# Altium schematic sheet Engine Bay and Rear Tube.SchDoc
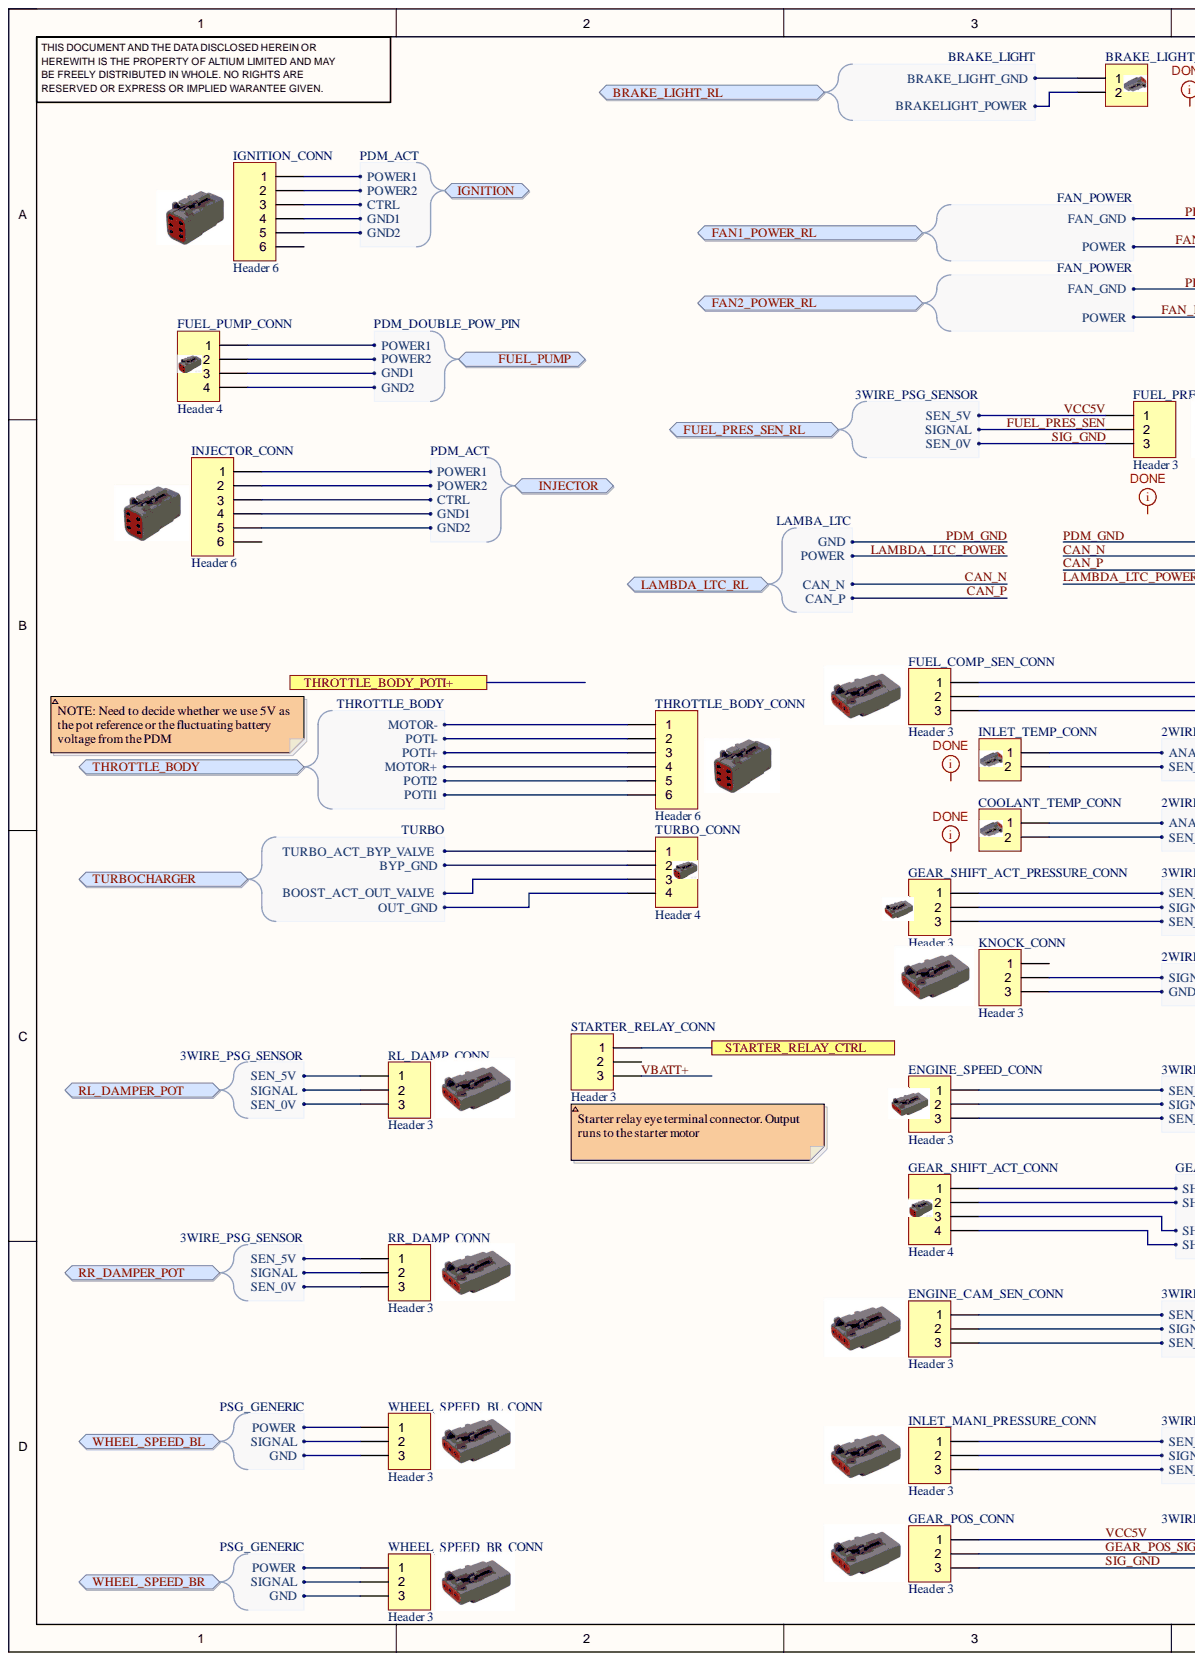
[diagram p0: Engine Bay and Rear Tube.SchDoc, left]
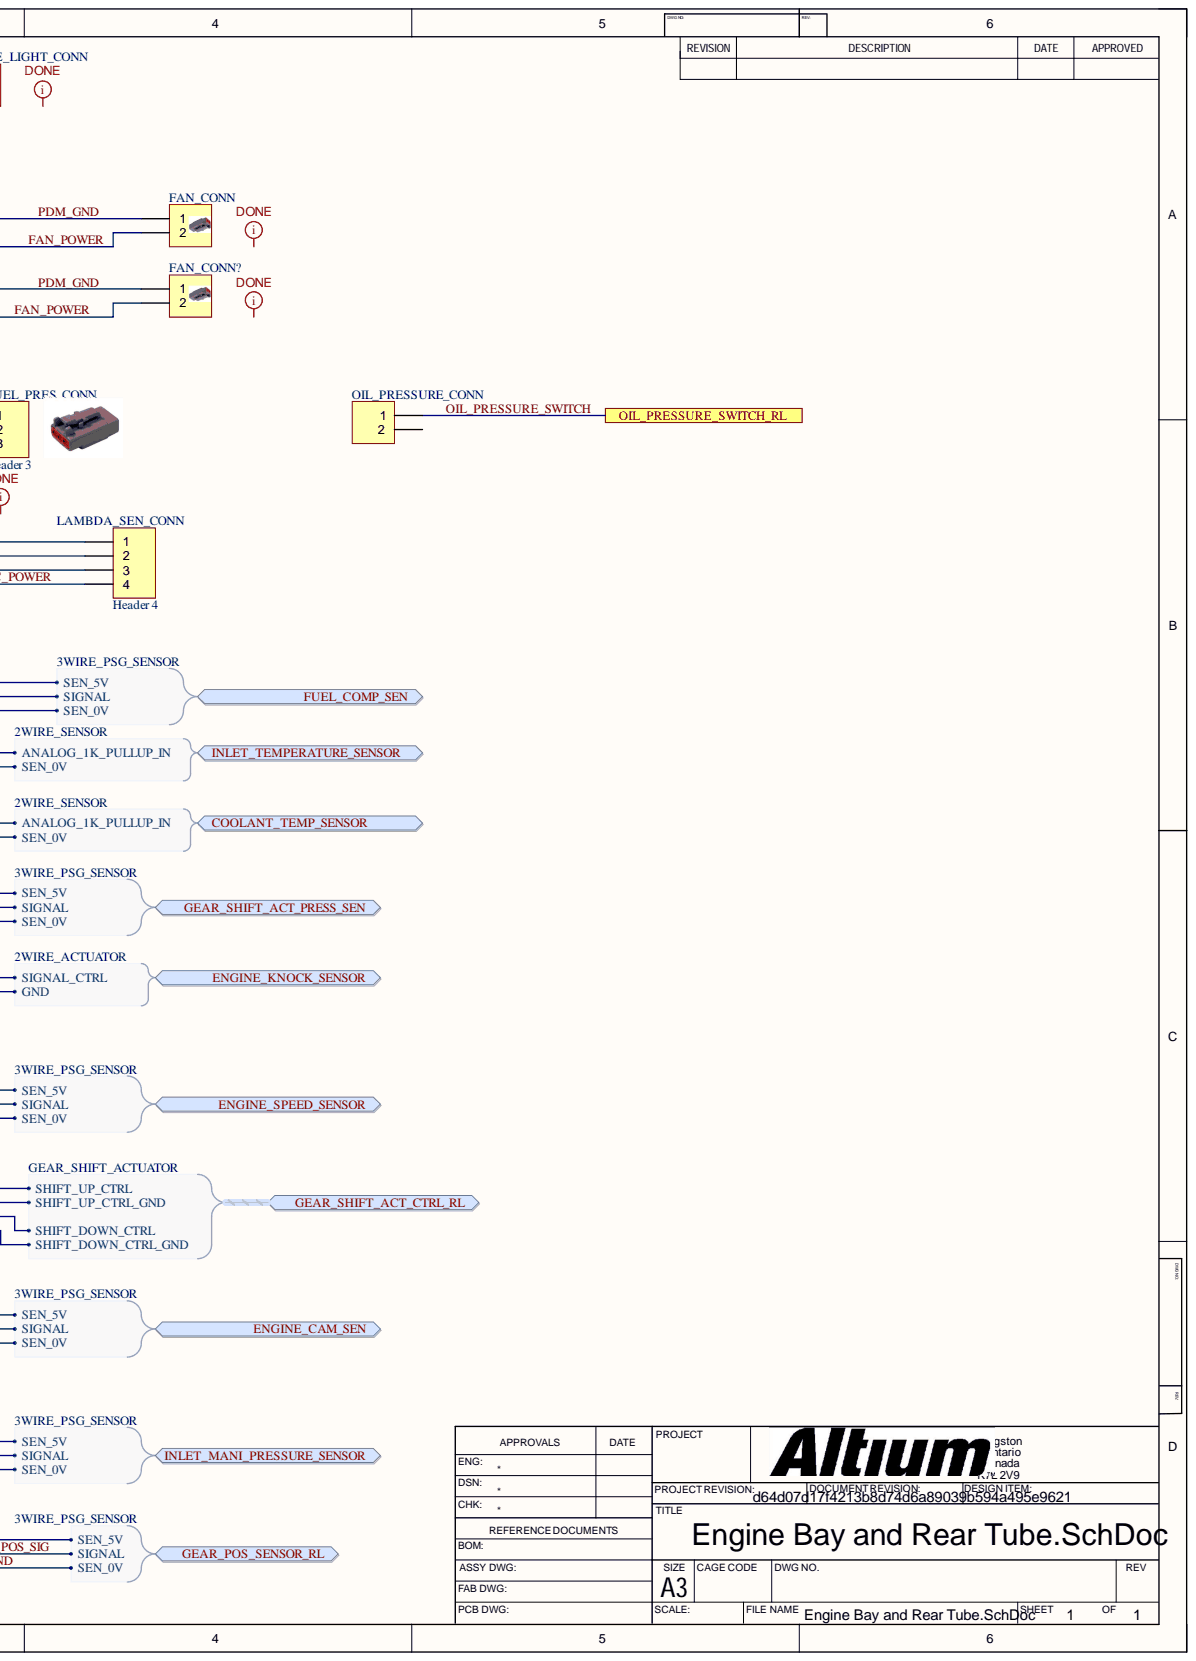
[diagram p1: Engine Bay and Rear Tube.SchDoc, right]

## Parts
BRAKE_LIGHT_CONN  Header 2  [HDR1X2]  (value not drawn)
COOLANT_TEMP_CONN  Header 2  [HDR1X2]  (value not drawn)
ENGINE_CAM_SEN_CONN  Header 3  [HDR1X3]
ENGINE_SPEED_CONN  Header 3  [HDR1X3]
FAN_CONN  Header 2  [HDR1X2]  (value not drawn)
FAN_CONN?  Header 2  [HDR1X2]  (value not drawn)
FUEL_COMP_SEN_CONN  Header 3  [HDR1X3]
FUEL_PRES_CONN  Header 3  [HDR1X3]
FUEL_PUMP_CONN  Header 4  [HDR1X4]
GEAR_POS_CONN  Header 3  [HDR1X3]
GEAR_SHIFT_ACT_CONN  Header 4  [HDR1X4]
GEAR_SHIFT_ACT_PRESSURE_CONN  Header 3  [HDR1X3]
IGNITION_CONN  Header 6  [HDR1X6]
INJECTOR_CONN  Header 6  [HDR1X6]
INLET_MANI_PRESSURE_CONN  Header 3  [HDR1X3]
INLET_TEMP_CONN  Header 2  [HDR1X2]
KNOCK_CONN  Header 3  [HDR1X3]
LAMBDA_SEN_CONN  Header 4  [HDR1X4]
OIL_PRESSURE_CONN  Header 2  [HDR1X2]  (value not drawn)
RL_DAMP_CONN  Header 3  [HDR1X3]
RR_DAMP_CONN  Header 3  [HDR1X3]
STARTER_RELAY_CONN  Header 3  [HDR1X3]
THROTTLE_BODY_CONN  Header 6  [HDR1X6]
TURBO_CONN  Header 4  [HDR1X4]
WHEEL_SPEED_BL_CONN  Header 3  [HDR1X3]
WHEEL_SPEED_BR_CONN  Header 3  [HDR1X3]

## Ports
BRAKE_LIGHT_RL, BRAKE_LIGHT_RL.BRAKE_LIGHT_GND, BRAKE_LIGHT_RL.BRAKELIGHT_POWER, COOLANT_TEMP_SENSOR, COOLANT_TEMP_SENSOR.ANALOG_1K_PULLUP_IN, COOLANT_TEMP_SENSOR.SEN_0V, ENGINE_CAM_SEN, ENGINE_CAM_SEN.SEN_0V, ENGINE_CAM_SEN.SEN_5V, ENGINE_CAM_SEN.SIGNAL, ENGINE_KNOCK_SENSOR, ENGINE_KNOCK_SENSOR.GND, ENGINE_KNOCK_SENSOR.SIGNAL_CTRL, ENGINE_SPEED_SENSOR, ENGINE_SPEED_SENSOR.SEN_0V, ENGINE_SPEED_SENSOR.SEN_5V, ENGINE_SPEED_SENSOR.SIGNAL, FAN1_POWER_RL, FAN1_POWER_RL.FAN_GND, FAN1_POWER_RL.POWER, FAN2_POWER_RL, FAN2_POWER_RL.FAN_GND, FAN2_POWER_RL.POWER, FUEL_COMP_SEN, FUEL_COMP_SEN.SEN_0V, FUEL_COMP_SEN.SEN_5V, FUEL_COMP_SEN.SIGNAL, FUEL_PRES_SEN_RL, FUEL_PRES_SEN_RL.SEN_0V, FUEL_PRES_SEN_RL.SEN_5V, FUEL_PRES_SEN_RL.SIGNAL, FUEL_PUMP, FUEL_PUMP.GND1, FUEL_PUMP.GND2, FUEL_PUMP.POWER1, FUEL_PUMP.POWER2, GEAR_POS_SENSOR_RL, GEAR_POS_SENSOR_RL.SEN_0V, GEAR_POS_SENSOR_RL.SEN_5V, GEAR_POS_SENSOR_RL.SIGNAL, GEAR_SHIFT_ACT_CTRL_RL, GEAR_SHIFT_ACT_CTRL_RL.SHIFT_DOWN_CTRL, GEAR_SHIFT_ACT_CTRL_RL.SHIFT_DOWN_CTRL_GND, GEAR_SHIFT_ACT_CTRL_RL.SHIFT_UP_CTRL, GEAR_SHIFT_ACT_CTRL_RL.SHIFT_UP_CTRL_GND, GEAR_SHIFT_ACT_PRESS_SEN, GEAR_SHIFT_ACT_PRESS_SEN.SEN_0V, GEAR_SHIFT_ACT_PRESS_SEN.SEN_5V, GEAR_SHIFT_ACT_PRESS_SEN.SIGNAL, IGNITION, IGNITION.CTRL, IGNITION.GND1, IGNITION.GND2, IGNITION.POWER1, IGNITION.POWER2, INJECTOR, INJECTOR.CTRL, INJECTOR.GND1, INJECTOR.GND2, INJECTOR.POWER1, INJECTOR.POWER2, INLET_MANI_PRESSURE_SENSOR, INLET_MANI_PRESSURE_SENSOR.SEN_0V, INLET_MANI_PRESSURE_SENSOR.SEN_5V, INLET_MANI_PRESSURE_SENSOR.SIGNAL, INLET_TEMPERATURE_SENSOR, INLET_TEMPERATURE_SENSOR.ANALOG_1K_PULLUP_IN, INLET_TEMPERATURE_SENSOR.SEN_0V, LAMBDA_LTC_RL, LAMBDA_LTC_RL.CAN_N, LAMBDA_LTC_RL.CAN_P, LAMBDA_LTC_RL.GND, LAMBDA_LTC_RL.POWER, OIL_PRESSURE_SWITCH_RL, RL_DAMPER_POT, RL_DAMPER_POT.SEN_0V, RL_DAMPER_POT.SEN_5V, RL_DAMPER_POT.SIGNAL, RR_DAMPER_POT, RR_DAMPER_POT.SEN_0V, RR_DAMPER_POT.SEN_5V, RR_DAMPER_POT.SIGNAL, STARTER_RELAY_CTRL, THROTTLE_BODY, THROTTLE_BODY.MOTOR+, THROTTLE_BODY.MOTOR-, THROTTLE_BODY.POTI1, THROTTLE_BODY.POTI2, THROTTLE_BODY.POTI+, THROTTLE_BODY.POTI-, THROTTLE_BODY_POTI+, TURBOCHARGER, TURBOCHARGER.BOOST_ACT_OUT_VALVE, TURBOCHARGER.BYP_GND, TURBOCHARGER.OUT_GND, TURBOCHARGER.TURBO_ACT_BYP_VALVE, WHEEL_SPEED_BL, WHEEL_SPEED_BL.GND, WHEEL_SPEED_BL.POWER, WHEEL_SPEED_BL.SIGNAL, WHEEL_SPEED_BR, WHEEL_SPEED_BR.GND, WHEEL_SPEED_BR.POWER, WHEEL_SPEED_BR.SIGNAL

## Nets
CAN_N: LAMBDA_SEN_CONN.2 ; port LAMBDA_LTC_RL
CAN_P: LAMBDA_SEN_CONN.3 ; port LAMBDA_LTC_RL
FAN_POWER: FAN_CONN.2 FAN_CONN?.2 ; port FAN1_POWER_RL, port FAN2_POWER_RL
FUEL_PRES_SEN: FUEL_PRES_CONN.2 ; port FUEL_PRES_SEN_RL
GEAR_POS_SIG: GEAR_POS_CONN.2 ; port GEAR_POS_SENSOR_RL
LAMBDA_LTC_POWER: LAMBDA_SEN_CONN.4 ; port LAMBDA_LTC_RL
OIL_PRESSURE_SWITCH: OIL_PRESSURE_CONN.1 ; port OIL_PRESSURE_SWITCH_RL
PDM_GND: FAN_CONN.1 FAN_CONN?.1 LAMBDA_SEN_CONN.1 ; port FAN1_POWER_RL, port FAN2_POWER_RL, port LAMBDA_LTC_RL
SIG_GND: FUEL_PRES_CONN.3 GEAR_POS_CONN.3 ; port FUEL_PRES_SEN_RL, port GEAR_POS_SENSOR_RL
STARTER_RELAY_CTRL: STARTER_RELAY_CONN.1 ; port STARTER_RELAY_CTRL
VBATT+: STARTER_RELAY_CONN.3
VCC5V: FUEL_PRES_CONN.1 GEAR_POS_CONN.1 ; port FUEL_PRES_SEN_RL, port GEAR_POS_SENSOR_RL
NetBRAKE_LIGHT_CONN_1: BRAKE_LIGHT_CONN.1 ; port BRAKE_LIGHT_RL
NetBRAKE_LIGHT_CONN_2: BRAKE_LIGHT_CONN.2 ; port BRAKE_LIGHT_RL
NetCOOLANT_TEMP_CONN_1: COOLANT_TEMP_CONN.1 ; port COOLANT_TEMP_SENSOR
NetCOOLANT_TEMP_CONN_2: COOLANT_TEMP_CONN.2 ; port COOLANT_TEMP_SENSOR
NetENGINE_CAM_SEN_CONN_1: ENGINE_CAM_SEN_CONN.1 ; port ENGINE_CAM_SEN
NetENGINE_CAM_SEN_CONN_2: ENGINE_CAM_SEN_CONN.2 ; port ENGINE_CAM_SEN
NetENGINE_CAM_SEN_CONN_3: ENGINE_CAM_SEN_CONN.3 ; port ENGINE_CAM_SEN
NetENGINE_SPEED_CONN_1: ENGINE_SPEED_CONN.1 ; port ENGINE_SPEED_SENSOR
NetENGINE_SPEED_CONN_2: ENGINE_SPEED_CONN.2 ; port ENGINE_SPEED_SENSOR
NetENGINE_SPEED_CONN_3: ENGINE_SPEED_CONN.3 ; port ENGINE_SPEED_SENSOR
NetFUEL_COMP_SEN_CONN_1: FUEL_COMP_SEN_CONN.1 ; port FUEL_COMP_SEN
NetFUEL_COMP_SEN_CONN_2: FUEL_COMP_SEN_CONN.2 ; port FUEL_COMP_SEN
NetFUEL_COMP_SEN_CONN_3: FUEL_COMP_SEN_CONN.3 ; port FUEL_COMP_SEN
NetFUEL_PUMP_CONN_1: FUEL_PUMP_CONN.1 ; port FUEL_PUMP
NetFUEL_PUMP_CONN_2: FUEL_PUMP_CONN.2 ; port FUEL_PUMP
NetFUEL_PUMP_CONN_3: FUEL_PUMP_CONN.3 ; port FUEL_PUMP
NetFUEL_PUMP_CONN_4: FUEL_PUMP_CONN.4 ; port FUEL_PUMP
NetGEAR_SHIFT_ACT_CONN_1: GEAR_SHIFT_ACT_CONN.1 ; port GEAR_SHIFT_ACT_CTRL_RL
NetGEAR_SHIFT_ACT_CONN_2: GEAR_SHIFT_ACT_CONN.2 ; port GEAR_SHIFT_ACT_CTRL_RL
NetGEAR_SHIFT_ACT_CONN_3: GEAR_SHIFT_ACT_CONN.3 ; port GEAR_SHIFT_ACT_CTRL_RL
NetGEAR_SHIFT_ACT_CONN_4: GEAR_SHIFT_ACT_CONN.4 ; port GEAR_SHIFT_ACT_CTRL_RL
NetGEAR_SHIFT_ACT_PRESSURE_CONN_1: GEAR_SHIFT_ACT_PRESSURE_CONN.1 ; port GEAR_SHIFT_ACT_PRESS_SEN
NetGEAR_SHIFT_ACT_PRESSURE_CONN_2: GEAR_SHIFT_ACT_PRESSURE_CONN.2 ; port GEAR_SHIFT_ACT_PRESS_SEN
NetGEAR_SHIFT_ACT_PRESSURE_CONN_3: GEAR_SHIFT_ACT_PRESSURE_CONN.3 ; port GEAR_SHIFT_ACT_PRESS_SEN
NetIGNITION_CONN_1: IGNITION_CONN.1 ; port IGNITION
NetIGNITION_CONN_2: IGNITION_CONN.2 ; port IGNITION
NetIGNITION_CONN_3: IGNITION_CONN.3 ; port IGNITION
NetIGNITION_CONN_4: IGNITION_CONN.4 ; port IGNITION
NetIGNITION_CONN_5: IGNITION_CONN.5 ; port IGNITION
NetINJECTOR_CONN_1: INJECTOR_CONN.1 ; port INJECTOR
NetINJECTOR_CONN_2: INJECTOR_CONN.2 ; port INJECTOR
NetINJECTOR_CONN_3: INJECTOR_CONN.3 ; port INJECTOR
NetINJECTOR_CONN_4: INJECTOR_CONN.4 ; port INJECTOR
NetINJECTOR_CONN_5: INJECTOR_CONN.5 ; port INJECTOR
NetINLET_MANI_PRESSURE_CONN_1: INLET_MANI_PRESSURE_CONN.1 ; port INLET_MANI_PRESSURE_SENSOR
NetINLET_MANI_PRESSURE_CONN_2: INLET_MANI_PRESSURE_CONN.2 ; port INLET_MANI_PRESSURE_SENSOR
NetINLET_MANI_PRESSURE_CONN_3: INLET_MANI_PRESSURE_CONN.3 ; port INLET_MANI_PRESSURE_SENSOR
NetINLET_TEMP_CONN_1: INLET_TEMP_CONN.1 ; port INLET_TEMPERATURE_SENSOR
NetINLET_TEMP_CONN_2: INLET_TEMP_CONN.2 ; port INLET_TEMPERATURE_SENSOR
NetKNOCK_CONN_2: KNOCK_CONN.2 ; port ENGINE_KNOCK_SENSOR
NetKNOCK_CONN_3: KNOCK_CONN.3 ; port ENGINE_KNOCK_SENSOR
NetRL_DAMP_CONN_1: RL_DAMP_CONN.1 ; port RL_DAMPER_POT
NetRL_DAMP_CONN_2: RL_DAMP_CONN.2 ; port RL_DAMPER_POT
NetRL_DAMP_CONN_3: RL_DAMP_CONN.3 ; port RL_DAMPER_POT
NetRR_DAMP_CONN_1: RR_DAMP_CONN.1 ; port RR_DAMPER_POT
NetRR_DAMP_CONN_2: RR_DAMP_CONN.2 ; port RR_DAMPER_POT
NetRR_DAMP_CONN_3: RR_DAMP_CONN.3 ; port RR_DAMPER_POT
NetTHROTTLE_BODY_CONN_1: THROTTLE_BODY_CONN.1 ; port THROTTLE_BODY
NetTHROTTLE_BODY_CONN_2: THROTTLE_BODY_CONN.2 ; port THROTTLE_BODY
NetTHROTTLE_BODY_CONN_3: THROTTLE_BODY_CONN.3 ; port THROTTLE_BODY
NetTHROTTLE_BODY_CONN_4: THROTTLE_BODY_CONN.4 ; port THROTTLE_BODY
NetTHROTTLE_BODY_CONN_5: THROTTLE_BODY_CONN.5 ; port THROTTLE_BODY
NetTHROTTLE_BODY_CONN_6: THROTTLE_BODY_CONN.6 ; port THROTTLE_BODY
NetTURBO_CONN_1: TURBO_CONN.1 ; port TURBOCHARGER
NetTURBO_CONN_2: TURBO_CONN.2 ; port TURBOCHARGER
NetTURBO_CONN_3: TURBO_CONN.3 ; port TURBOCHARGER
NetTURBO_CONN_4: TURBO_CONN.4 ; port TURBOCHARGER
NetWHEEL_SPEED_BL_CONN_1: WHEEL_SPEED_BL_CONN.1 ; port WHEEL_SPEED_BL
NetWHEEL_SPEED_BL_CONN_2: WHEEL_SPEED_BL_CONN.2 ; port WHEEL_SPEED_BL
NetWHEEL_SPEED_BL_CONN_3: WHEEL_SPEED_BL_CONN.3 ; port WHEEL_SPEED_BL
NetWHEEL_SPEED_BR_CONN_1: WHEEL_SPEED_BR_CONN.1 ; port WHEEL_SPEED_BR
NetWHEEL_SPEED_BR_CONN_2: WHEEL_SPEED_BR_CONN.2 ; port WHEEL_SPEED_BR
NetWHEEL_SPEED_BR_CONN_3: WHEEL_SPEED_BR_CONN.3 ; port WHEEL_SPEED_BR
N00001:

Not connected: IGNITION_CONN 6; INJECTOR_CONN 6; KNOCK_CONN 1; OIL_PRESSURE_CONN 2; STARTER_RELAY_CONN 2

## Library data of the parts
BRAKE_LIGHT_CONN COOLANT_TEMP_CONN FAN_CONN FAN_CONN? INLET_TEMP_CONN OIL_PRESSURE_CONN = Header 2: Header, 2-Pin; Component Kind: Standard; LatestRevisionDate: 17-Jul-2002; LatestRevisionNote: Re-released for DXP Platform.; Library Name: Miscellaneous Connectors.IntLib; Signal Integrity: Connector; Publisher: Altium Limited
ENGINE_CAM_SEN_CONN ENGINE_SPEED_CONN FUEL_COMP_SEN_CONN FUEL_PRES_CONN GEAR_POS_CONN GEAR_SHIFT_ACT_PRESSURE_CONN INLET_MANI_PRESSURE_CONN KNOCK_CONN RL_DAMP_CONN RR_DAMP_CONN STARTER_RELAY_CONN WHEEL_SPEED_BL_CONN WHEEL_SPEED_BR_CONN = Header 3: Header, 3-Pin; Component Kind: Standard; LatestRevisionDate: 17-Jul-2002; LatestRevisionNote: Re-released for DXP Platform.; Library Name: Miscellaneous Connectors.IntLib; Signal Integrity: Connector; Publisher: Altium Limited
FUEL_PUMP_CONN GEAR_SHIFT_ACT_CONN LAMBDA_SEN_CONN TURBO_CONN = Header 4: Header, 4-Pin; Component Kind: Standard; LatestRevisionDate: 17-Jul-2002; LatestRevisionNote: Re-released for DXP Platform.; Library Name: Miscellaneous Connectors.IntLib; Signal Integrity: Connector; Publisher: Altium Limited
IGNITION_CONN INJECTOR_CONN THROTTLE_BODY_CONN = Header 6: Header, 6-Pin; Component Kind: Standard; LatestRevisionDate: 17-Jul-2002; LatestRevisionNote: Re-released for DXP Platform.; Library Name: Miscellaneous Connectors.IntLib; Signal Integrity: Connector; Publisher: Altium Limited
